# Altium schematic sheet uC_Aux.SchDoc(uC_Aux)
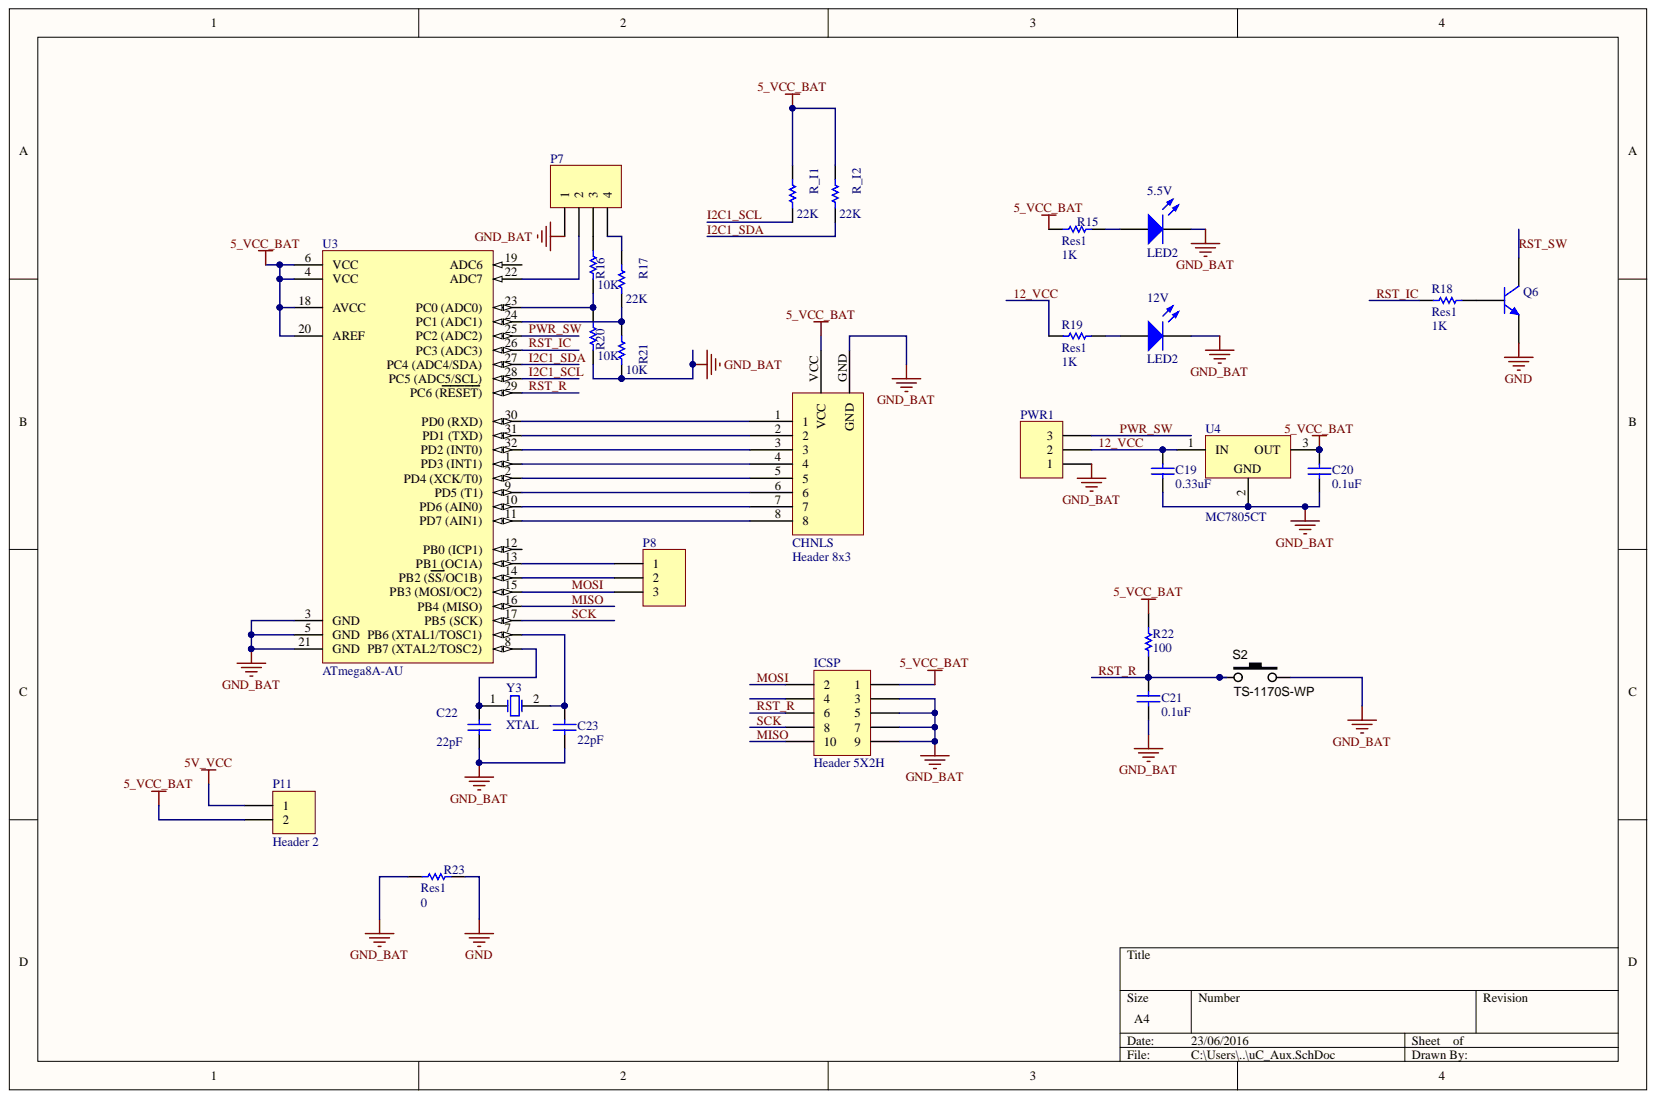
[diagram p0: uC_Aux.SchDoc]

## Parts
5.5V  LED2  [6-0805_L]
12V  LED2  [6-0805_L]
C19  value 0.33uF  [6-0805_L]  (hidden comment: Cap)
C20  value 0.1uF  [C0805]  (hidden comment: Cap)
C21  value 0.1uF  [C1206]  (hidden comment: Cap)
C22  value 22pF  [6-0805_L]  (hidden comment: Cap Pol1)
C23  value 22pF  [6-0805_L]  (hidden comment: Cap Pol1)
CHNLS  Header 8x3  [Header 8x3_mirror]
ICSP  Header 5X2H  [HDR2X5]
P7  Header 4  [HDR1X4]  (value not drawn)
P8  Header 3  [HDR1X3]  (value not drawn)
P11  Header 2  [HDR1X2]
PWR1  Header 3  [HDR1X3]  (value not drawn)
Q6  2N3904  [SOT-23_N]  (value not drawn)
R15  Res1  value 1K  [C0805]
R16  value 10K  [C0805]  (hidden comment: Res1)
R17  value 22K  [C0805]  (hidden comment: Res1)
R18  Res1  value 1K  [C1206]
R19  Res1  value 1K  [6-0805_L]
R20  value 10K  [C1206]  (hidden comment: Res1)
R21  value 10K  [C0805]  (hidden comment: Res1)
R22  value 100  [C0805]  (hidden comment: Res1)
R23  Res1  value 0  [C0805]
R_I1  value 22K  [C0805]  (hidden comment: Res1)
R_I2  value 22K  [C0805]  (hidden comment: Res1)
S2  TS-1170S-WP  [TS-1170S]
U3  ATmega8A-AU  [32A_N]
U4  MC7805CT  [TO-220-AB]
Y3  XTAL  [BCY-W2/E4.7]

## Nets
5_VCC_BAT: C20.2 CHNLS.VCC ICSP.1 P11.2 R15.1 R22.1 R_I1.2 R_I2.2 U3.4(VCC) U3.6(VCC) U3.18(AVCC) U3.20(AREF) U4.3
5V_VCC: P11.1
GND: Q6.1 R23.2
GND_BAT: 5.5V.2 12V.2 C19.1 C20.1 C21.1 C22.1 C23.1 CHNLS.GND ICSP.3 ICSP.5 ICSP.7 ICSP.9 P7.1 PWR1.1 R20.1 R21.1 R23.1 S2.2 U3.3(GND) U3.5(GND) U3.21(GND) U4.2
12_VCC: C19.2 PWR1.2 R19.2 U4.1
I2C1_SCL: R_I1.1 U3.28(PC5_(ADC5/SCL))
I2C1_SDA: R_I2.1 U3.27(PC4_(ADC4/SDA))
MISO: ICSP.10 U3.16(PB4_(MISO))
MOSI: ICSP.2 P8.3 U3.15(PB3_(MOSI/OC2))
PWR_SW: PWR1.3 U3.25(PC2_(ADC2))
RST_IC: R18.2 U3.26(PC3_(ADC3))
RST_R: C21.2 ICSP.6 R22.2 S2.1 U3.29(PC6_(RESET))
RST_SW: Q6.3
SCK: ICSP.8 U3.17(PB5_(SCK))
Net5.5V_1: 5.5V.1 R15.2
Net12V_1: 12V.1 R19.1
NetC22_2: C22.2 U3.8 Y3.1
NetC23_2: C23.2 U3.7 Y3.2
NetCHNLS_1: CHNLS.1 U3.30(PD0_(RXD))
NetCHNLS_2: CHNLS.2 U3.31(PD1_(TXD))
NetCHNLS_3: CHNLS.3 U3.32(PD2_(INT0))
NetCHNLS_4: CHNLS.4 U3.1(PD3_(INT1))
NetCHNLS_5: CHNLS.5 U3.2(PD4_(XCK/T0))
NetCHNLS_6: CHNLS.6 U3.9(PD5_(T1))
NetCHNLS_7: CHNLS.7 U3.10(PD6_(AIN0))
NetCHNLS_8: CHNLS.8 U3.11(PD7_(AIN1))
NetP7_2: P7.2 U3.22(ADC7)
NetP7_3: P7.3 R16.2
NetP7_4: P7.4 R17.2
NetP8_1: P8.1 U3.13(PB1_(OC1A))
NetP8_2: P8.2 U3.14(PB2_(SS/OC1B))
NetQ6_2: Q6.2 R18.1
NetR16_1: R16.1 R20.2 U3.23(PC0_(ADC0))
NetR17_1: R17.1 R21.2 U3.24(PC1_(ADC1))

Not connected: ICSP 4; U3 12(PB0_(ICP1)) 19(ADC6)

## Library data of the parts
5.5V 12V = LED2: Typical RED, GREEN, YELLOW, AMBER GaAs LED; Component Kind: Standard; LatestRevisionDate: 17-Jul-2002; LatestRevisionNote: Re-released for DXP Platform.; Library Name: Miscellaneous Devices.IntLib; PackageDescription: SM LED; 2 Flat Leads; PackageReference: 3.2X1.6X1.1; PackageVersion: Feb-2002; Published: 8-Jun-2000; Simulation: LED2; Publisher: Altium Limited
C19-C23 = Cap: Capacitor; Component Kind: Standard; LatestRevisionDate: 17-Jul-2002; LatestRevisionNote: Re-released for DXP Platform.; Library Name: Miscellaneous Devices.IntLib; PackageDescription: Radial Cap, Thru-Hole; 2 Leads; 0.3 in Pin Spacing; PackageReference: RAD-0.3; Published: 8-Jun-2000; Signal Integrity: Cap; Simulation: CAP; Publisher: Altium Limited
ICSP = Header 5X2H: Header, 5-Pin, Dual row, Right Angle; Component Kind: Standard; LatestRevisionDate: 17-Jul-2002; LatestRevisionNote: Re-released for DXP Platform.; Library Name: Miscellaneous Connectors.IntLib; Signal Integrity: Connector; Publisher: Altium Limited
P7 = Header 4: Header, 4-Pin; Component Kind: Standard; LatestRevisionDate: 17-Jul-2002; LatestRevisionNote: Re-released for DXP Platform.; Library Name: Miscellaneous Connectors.IntLib; Signal Integrity: Connector; Publisher: Altium Limited
P8 PWR1 = Header 3: Header, 3-Pin; Component Kind: Standard; LatestRevisionDate: 17-Jul-2002; LatestRevisionNote: Re-released for DXP Platform.; Library Name: Miscellaneous Connectors.IntLib; Signal Integrity: Connector; Publisher: Altium Limited
P11 = Header 2: Header, 2-Pin; Component Kind: Standard; LatestRevisionDate: 17-Jul-2002; LatestRevisionNote: Re-released for DXP Platform.; Library Name: Miscellaneous Connectors.IntLib; Signal Integrity: Connector; Publisher: Altium Limited
Q6 = 2N3904: NPN General Purpose Amplifier; Component Kind: Standard; ComponentLink1Description: Manufacturer Link; ComponentLink1URL: http://www.fairchildsemi.com/; ComponentLink2Description: Datasheet; ComponentLink2URL: http://www.fairchildsemi.com/ds/2N%2F2N3904.pdf; DatasheetVersion: 1997; LatestRevisionDate: 15-Jan-2003; LatestRevisionNote: PCB Footprint 'BCY-W3/D4.7' replaced; Library Name: Miscellaneous Devices.IntLib; PackageDescription: TO, Flat Index; 3 In-Line, Axial Leads; Body Dia. 4.5mm; Leads 0.51 x 0.48 mm (max); PackageReference: TO-92A; PackageVersion: Sep-1998; Published: 8-Jun-2000; Signal Integrity: 2N3904; Simulation: 2N3904; Publisher: Altium Limited
R15-R23 R_I1 R_I2 = Res1: Resistor; Component Kind: Standard; LatestRevisionDate: 17-Jul-2002; LatestRevisionNote: Re-released for DXP Platform.; Library Name: Miscellaneous Devices.IntLib; PackageDescription: Axial Device, Thru-Hole; 2 Leads; 0.3 in Pin Spacing; PackageReference: AXIAL-0.3; Published: 8-Jun-2000; Signal Integrity: Res; Simulation: RESISTOR; Publisher: Altium Limited
S2 = SW_SMD_PB_7.0mm: Switch SMD Tact 7.0mm Stem; Manufacturer 1: Bond-Tact; Manufacturer Part Number 1: TS-1170S-WP-200; Function: On/Off; SCDType: source; Component Kind: Standard; ComponentLink1Description: http://www.bondtact.com.hk/zaspx/productd.aspx?catno=3&subcatid=3&PageNo=2&productno=40; ComponentLink1URL: http://www.bondtact.com.hk/zaspx/productd.aspx?catno=3&subcatid=3&PageNo=2&productno=40; Library Name: PBL - Switches.SCHLIB; Package / Case: 6.5x6.5mm; 7.0mm stem
U3 = ATmega8A-AU: 8-bit AVR Microcontroller, 8KB Flash, 512 Bytes EEPROM, 1KB SRAM, 32-pin TQFP, Industrial Grade (-40°C to 85°C); Code_JEDEC: MS-026-ABA; Component Kind: Standard; ComponentLink1Description: Manufacturer Link; ComponentLink1URL: http://www.atmel.com/products/avr/default.asp?; ComponentLink2Description: ATmega8A Datasheet; ComponentLink2URL: http://www.atmel.com/dyn/resources/prod_documents/doc8159.pdf; ComponentLink3Description: ATmega8A Summary; ComponentLink3URL: http://www.atmel.com/dyn/resources/prod_documents/8159S.pdf; ComponentLink4Description: Atmel.com Home; ComponentLink4URL: http://www.atmel.com; DatasheetVersion: revC, Jul-2009; Library Name: Atmel Microcontroller 8-Bit megaAVR.IntLib; PackageDescription: 32-Lead, 7x7x1.0 mm Body, 0.8mm Lead Pitch, Thin Profile Plastic Quad Flat Package (TQFP); PackageReference: 32A; PackageVersion: revB, Oct-2001; Published: 1-Apr-2010; Supplier 1: Digi-Key; Supplier 2: Farnell; Supplier 3: Mouser; Supplier Part Number 1: ATMEGA8A-AU-ND; Supplier Part Number 2: 1748532; Supplier Part Number 3: 556-ATMEGA8A-AU; Publisher: Altium Limited
U4 = MC7805CT: 3-Terminal Positive Fixed Voltage Regulator; Note: Pin 2 is electrically connected to TAB; Code_JEDEC: TO-262-AA; Component Kind: Standard; ComponentLink1Description: Manufacturer Link; ComponentLink1URL: http://www.onsemi.com/site/products/taxonomy/0,4438,503,00.html; ComponentLink2Description: Datasheet; ComponentLink2URL: http://www.onsemi.com/pub/Collateral/MC7800-D.PDF; DatasheetVersion: 1988; Library Name: Motorola Power Mgt Voltage Regulator.IntLib; PackageDescription: TO, Thru-Hole, Vertical, Heatsink Mounted; 3 In-Line Leads; Pitch 2.54 mm; PackageReference: 221A-04; Published: 17-Jul-2002; Publisher: Altium Limited
Y3 = XTAL: Crystal Oscillator; Component Kind: Standard; LatestRevisionDate: 17-Jul-2002; LatestRevisionNote: Re-released for DXP Platform.; Library Name: Miscellaneous Devices.IntLib; PackageDescription: Crystal, Thru-Hole; 2 Leads; Body 3.1 x 8.2 mm (Dia.xH); PackageReference: R38; Published: 8-Jun-2000; Simulation: XTAL; Publisher: Altium Limited
CHNLS = Header 8x3: Component Kind: Standard; Library Name: Conector.SchLib
